# Altium schematic sheet Audio Codec.SchDoc
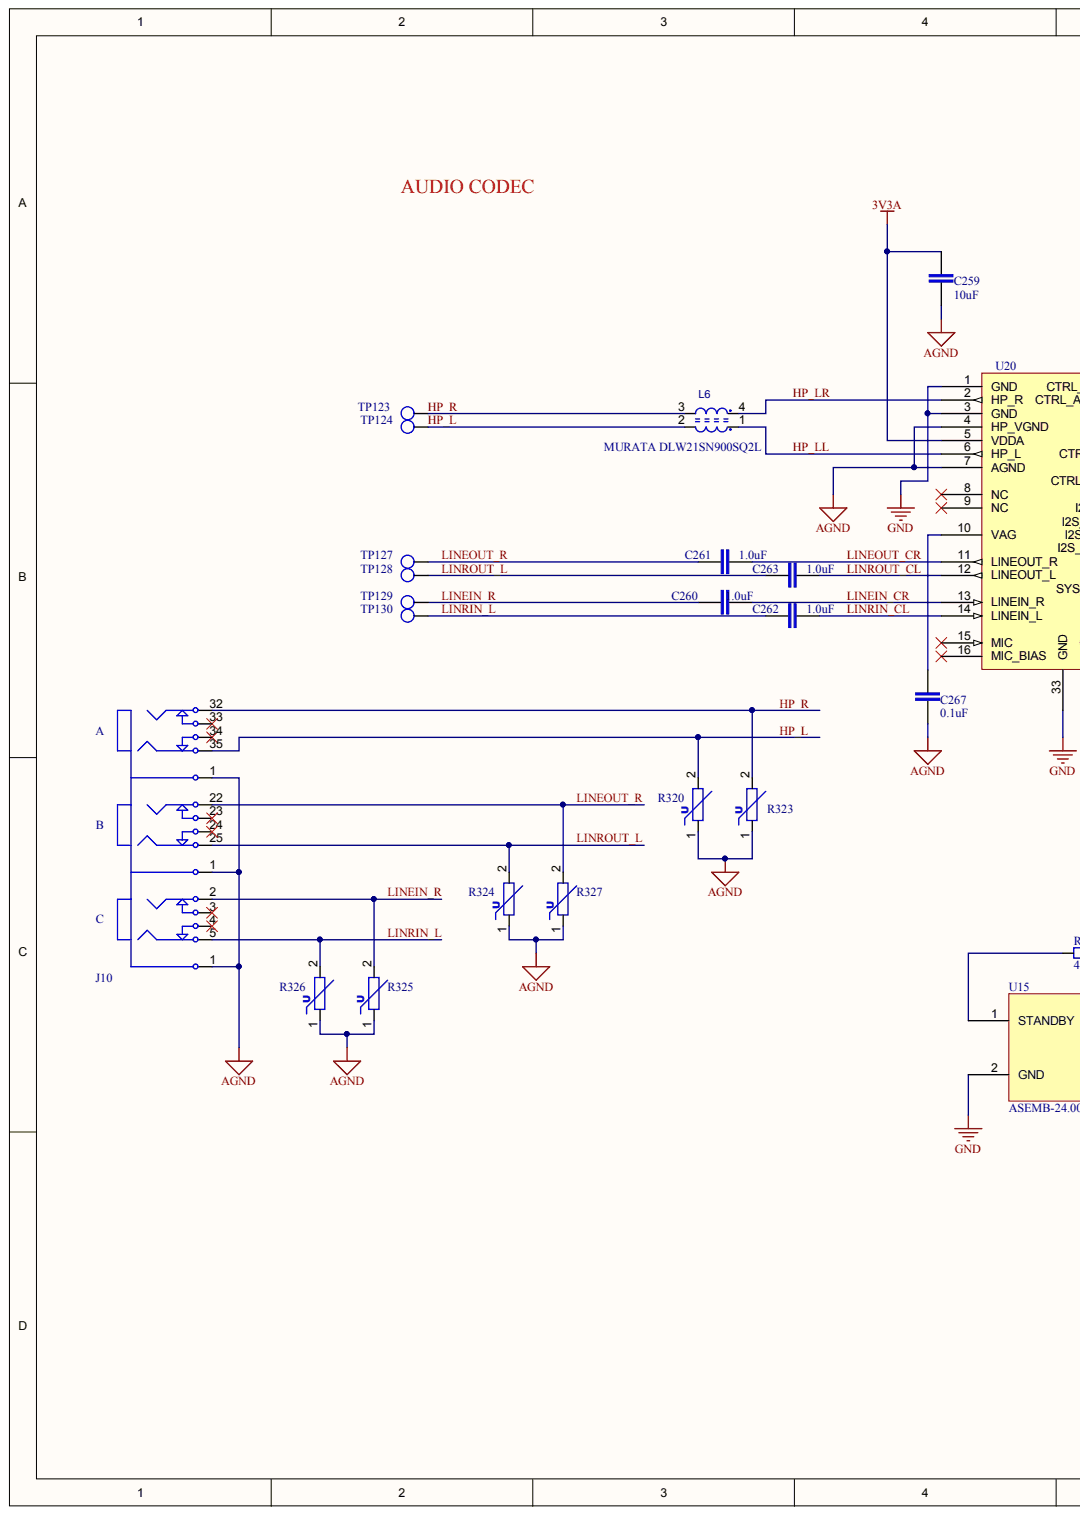
[diagram p0: Audio Codec.SchDoc, left]
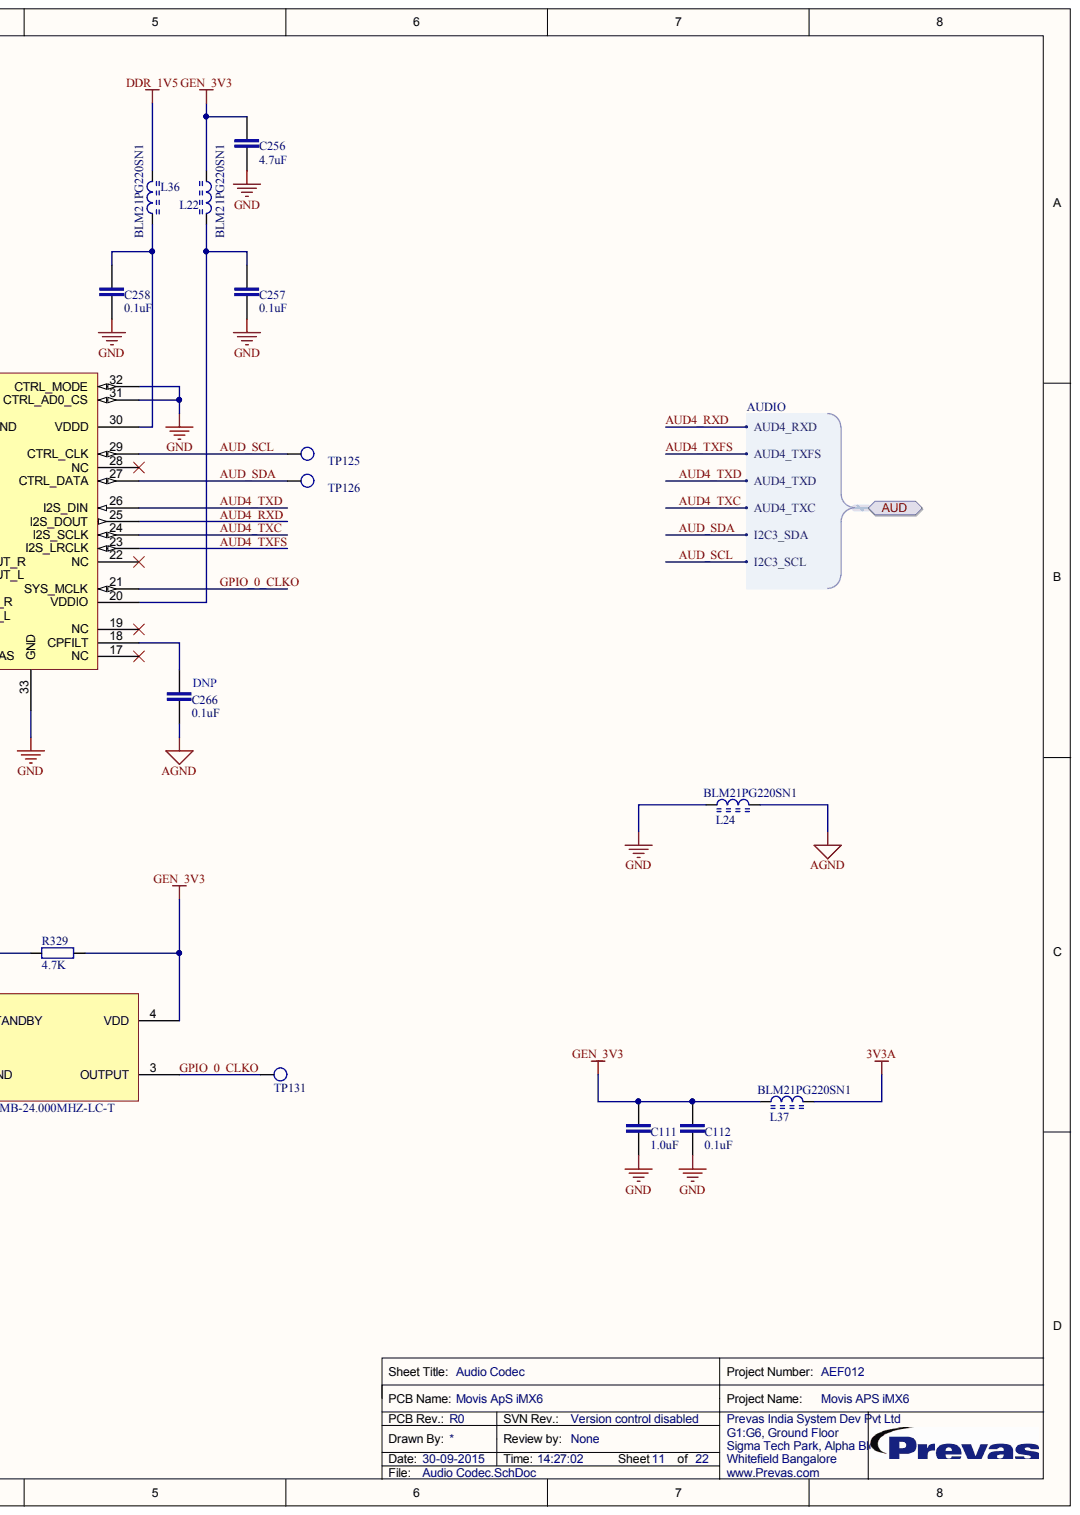
[diagram p1: Audio Codec.SchDoc, right]

## Parts
C111  1.0uF  [CAPC1608X90N]
C112  0.1uF  [CAPC1005X55N]
C256  4.7uF  [CAPC1608X90N]
C257  0.1uF  [CAPC1005X55N]
C258  0.1uF  [CAPC1005X55N]
C259  10uF  [CAPC1608X95N]
C260  1.0uF  [CAPC1608X90N]
C261  1.0uF  [CAPC1608X90N]
C262  1.0uF  [CAPC1608X90N]
C263  1.0uF  [CAPC1608X90N]
C266  0.1uF  Fitted: DNP  [CAPC1005X55N]
C267  0.1uF  [CAPC1005X55N]
J10  STX-4335-5BGP-S1  [JACK_3.5MM_STACKED]  (value not drawn)
L6  MURATA DLW21SN900SQ2L  [IND_DLP11S_DLP31S]
L22  BLM21PG220SN1  [INDC2012X110N]
L24  BLM21PG220SN1  [INDC2012X110N]
L36  BLM21PG220SN1  [INDC2012X110N]
L37  BLM21PG220SN1  [INDC2012X110N]
R320  V9MLA0603LNR  [RESC1608X55N]  (value not drawn)
R323  V9MLA0603LNR  [RESC1608X55N]  (value not drawn)
R324  V9MLA0603LNR  [RESC1608X55N]  (value not drawn)
R325  V9MLA0603LNR  [RESC1608X55N]  (value not drawn)
R326  V9MLA0603LNR  [RESC1608X55N]  (value not drawn)
R327  V9MLA0603LNR  [RESC1608X55N]  (value not drawn)
R329  4.7K  [RESC1608X55N]
TP123  Testpoint  [TP_SMD]  (value not drawn)  (no BOM)
TP124  Testpoint  [TP_SMD]  (value not drawn)  (no BOM)
TP125  Testpoint  [TP_SMD]  (value not drawn)  (no BOM)
TP126  Testpoint  [TP_SMD]  (value not drawn)  (no BOM)
TP127  Testpoint  [TP_SMD]  (value not drawn)  (no BOM)
TP128  Testpoint  [TP_SMD]  (value not drawn)  (no BOM)
TP129  Testpoint  [TP_SMD]  (value not drawn)  (no BOM)
TP130  Testpoint  [TP_SMD]  (value not drawn)  (no BOM)
TP131  Testpoint  [TP_SMD]  (value not drawn)  (no BOM)
U15  ASEMB-24.000MHZ-LC-T  [DFN210P323X90-4N]
U20  SGTL5000  [QFN50P500X500X90-33N]  (value not drawn)

## Ports
AUD, AUD.AUD4_RXD, AUD.AUD4_TXC, AUD.AUD4_TXD, AUD.AUD4_TXFS, AUD.I2C3_SCL, AUD.I2C3_SDA

## Nets
3V3A: C259.2 L37.2 U20.5(VDDA)
AGND: C259.1 C266.1 C267.1 J10.1 L24.2 R320.1 R323.1 R324.1 R325.1 R326.1 R327.1 U20.4(HP_VGND) U20.7(AGND)
DDR_1V5: L36.2
GEN_3V3: C111.2 C112.2 C256.2 L22.1 L37.1 R329.1 U15.4(VDD)
GND: C111.1 C112.1 C256.1 C257.1 C258.1 L24.1 U15.2(GND) U20.1(GND) U20.3(GND) U20.31(CTRL_AD0_CS) U20.32(CTRL_MODE) U20.33(GND)
AUD4_RXD: U20.25(I2S_DOUT) ; port AUD
AUD4_TXC: U20.24(I2S_SCLK) ; port AUD
AUD4_TXD: U20.26(I2S_DIN) ; port AUD
AUD4_TXFS: U20.23(I2S_LRCLK) ; port AUD
AUD_SCL: TP125.1 U20.29(CTRL_CLK) ; port AUD
AUD_SDA: TP126.1 U20.27(CTRL_DATA) ; port AUD
GPIO_0_CLKO: TP131.1 U15.3(OUTPUT) U20.21(SYS_MCLK)
HP_L: J10.35 L6.2 R320.2 TP124.1
HP_LL: L6.1 U20.6(HP_L)
HP_LR: L6.4 U20.2(HP_R)
HP_R: J10.32 L6.3 R323.2 TP123.1
LINEIN_CR: C260.1 U20.13(LINEIN_R)
LINEIN_R: C260.2 J10.2 R325.2 TP129.1
LINEOUT_CR: C261.1 U20.11(LINEOUT_R)
LINEOUT_R: C261.2 J10.22 R327.2 TP127.1
LINRIN_CL: C262.2 U20.14(LINEIN_L)
LINRIN_L: C262.1 J10.5 R326.2 TP130.1
LINROUT_CL: C263.2 U20.12(LINEOUT_L)
LINROUT_L: C263.1 J10.25 R324.2 TP128.1
NetC257_2: C257.2 L22.2 U20.20(VDDIO)
NetC258_2: C258.2 L36.1 U20.30(VDDD)
NetC266_2: C266.2 U20.18(CPFILT)
NetC267_2: C267.2 U20.10(VAG)
NetR329_2: R329.2 U15.1(STANDBY)

Not connected: J10 3 4 23 24 33 34; U20 8(NC) 9(NC) 15(MIC) 16(MIC_BIAS) 17(NC) 19(NC) 22(NC) 28(NC)

## Library data of the parts
C111 C260-C263 = INNISI-79: CAP CER 1UF 16V 10% X7R 0603; ref_part_type: /capacitor/non_polarized/ceramic/; source_manufacturer_1: Murata; source_manufacturer_1_pn: GRM188R71C105KE15D; cae_altium_pcb_status: Prototype; Database Table Name: altium_default; db_rev: 7576; elec_temp_range: -55 to +125; phy_height: 0.90mm; phy_mounting: SMD; phy_packaging_type: 0603 (1608 Metric); ref_datasheet: INNISI-33; ref_keyword: Ceramic Capacitor 0603; cae_altium_sch_status: Prototype; Component Kind: Standard; ComponentLink1Description: Datasheet; ComponentLink1URL: https://cop201.prevas.dk/viewvc/datasheet/INNISI-33.pdf?root=hw_components&view=co; db_created: 2015-08-03 05:39:06.0; db_last_updated: 03-08-2015 05:39:08; db_local_id: INNISI-79; db_status: Prototype; elec_tolerance: 10%; elec_value: 1.0uF; elec_voltage_rating: 16V; phy_material: X7R; Library Name: altium_mysql.dblib
C112 C257 C258 C266 C267 = INNISI-73: CAP CER 0.1UF 50V 10% X5R 0402; ref_part_type: /capacitor/non_polarized/ceramic/; source_manufacturer_1: TDK Corporation; source_manufacturer_1_pn: C1005X5R1H104K050BB; cae_altium_pcb_status: Prototype; Database Table Name: altium_default; db_rev: 7569; elec_temp_range: -55 to +85 C; phy_height: 0.55mm; phy_mounting: SMD; phy_packaging_type: 0402; ref_datasheet: INNISI-71; cae_altium_sch_status: Prototype; Component Kind: Standard; ComponentLink1Description: Datasheet; ComponentLink1URL: https://cop201.prevas.dk/viewvc/datasheet/INNISI-71.pdf?root=hw_components&view=co; db_created: 2015-08-03 03:34:59.0; db_last_updated: 03-08-2015 03:35:01; db_local_id: INNISI-73; db_status: Prototype; elec_tolerance: ±10%; elec_value: 0.1uF; elec_voltage_rating: 50V; phy_material: X5R; ref_keyword: CAP CER 0.1UF 50V 10% X5R 0402; Library Name: altium_mysql.dblib
C256 = INNISI-102: Ceramic capacitor X5R 0603; ref_part_type: /capacitor/non_polarized/ceramic/; source_manufacturer_1: TAIYO YUDEN; source_manufacturer_1_pn: EMK107ABJ475KA-T; cae_altium_pcb_status: Prototype; Database Table Name: altium_default; db_rev: 7663; elec_temp_range: -55 to +85; elec_tolerance: 10%; elec_voltage_rating: 16V; phy_height: 0.90mm; phy_mounting: SMD; phy_packaging_type: 0603; ref_keyword: Multilayer chip capacitor; cae_altium_sch_status: Prototype; Component Kind: Standard; ComponentLink1Description: Datasheet; db_created: 2015-08-04 09:58:51.0; db_last_updated: 04-08-2015 09:58:53; db_local_id: INNISI-102; db_status: Prototype; elec_value: 4.7uF; phy_material: X5R; Library Name: altium_mysql.dblib
C259 = INNISI-78: CAP CER 10UF 16V 10% X5R 0603; ref_part_type: /capacitor/non_polarized/ceramic/; source_manufacturer_1: Murata; source_manufacturer_1_pn: GRM188R61C106KAALD; cae_altium_pcb_status: Prototype; Database Table Name: altium_default; db_rev: 7574; elec_temp_range: -55 to +85C; phy_height: 0.95mm; phy_mounting: SMD; phy_packaging_type: 0603; ref_datasheet: INNISI-75; ref_keyword: Ceramic capacitor X5R 0603; cae_altium_sch_status: Prototype; Component Kind: Standard; ComponentLink1Description: Datasheet; ComponentLink1URL: https://cop201.prevas.dk/viewvc/datasheet/INNISI-75.pdf?root=hw_components&view=co; db_created: 2015-08-03 05:08:42.0; db_last_updated: 03-08-2015 05:08:44; db_local_id: INNISI-78; db_status: Prototype; elec_tolerance: 10%; elec_value: 10uF; elec_voltage_rating: 16V; phy_material: X5R; Library Name: altium_mysql.dblib
J10 = INNISI-62: Phone Connectors 3.5mm STACKED STEREO 5P BLU/5P GRN/5P PNK; ref_part_type: /connector/5 pins/; source_manufacturer_1: Kycon; cae_altium_pcb_status: Prototype; Database Table Name: altium_default; db_rev: 7461; elec_current_rating: 1A; elec_temp_range: -25C to +100C; elec_voltage_rating: 16V; phy_material: Copper Alloy; phy_mounting: Leaded; ref_datasheet: INNISI-61; ref_keyword: Audio Connectors; cae_altium_sch_status: Prototype; Component Kind: Standard; ComponentLink1Description: Datasheet; ComponentLink1URL: https://cop201.prevas.dk/viewvc/datasheet/INNISI-61.pdf?root=hw_components&view=co; db_created: 2015-06-18 05:59:17.0; db_last_updated: 18-06-2015 06:09:27; db_local_id: INNISI-62; db_status: Prototype; elec_value: STX-4335-5BGP-S1; source_manufacturer_1_pn: STX-4335-5BGP-S1; Library Name: altium_mysql.dblib
L6 = NIMA-45: Choke, Common Mode 90R@100MHz; ref_part_type: /inductor/lossy/; source_manufacturer_1_pn: DLP11SN900HL2L; cae_altium_pcb_status: Prototype; Database Table Name: altium_default; db_rev: 1364; elec_current_rating: 0.15A; elec_frequency_rating: 100MHz; elec_temp_range: -40 to 85; elec_tolerance: 20%; elec_voltage_rating: 5V; phy_height: 0.92mm; phy_material: Ferrite; phy_mounting: SMD; phy_packaging_type: 0504; ref_datasheet: NIMA-38; cae_altium_sch_status: Prototype; Component Kind: Standard; ComponentLink1Description: Datasheet; ComponentLink1URL: https://cop201.prevas.dk/viewvc/datasheet/NIMA-38.pdf?root=hw_components&view=co; db_created: 2009-03-25 17:22:37; db_last_updated: 02-06-2009 11:13:05; db_local_id: NIMA-45; db_status: Prototype; elec_value: MURATA DLW21SN900SQ2L; Mounted: Yes; ref_keyword: Choke, Common Mode 90R@100MHz; source_manufacturer_1: Murata; Library Name: altium_mysql.dblib
L22 L24 L36 L37 = INNISI-22: EMI Filter Beads, Chips & Arrays; ref_part_type: /inductor/power/; source_manufacturer_1: Murata; cae_altium_pcb_status: Prototype; Database Table Name: altium_default; db_rev: 7355; elec_current_rating: 6 A; elec_frequency_rating: 100 MHz; elec_temp_range: - 55 C to + 125 C; elec_tolerance: 25 %; phy_mounting: SMD; phy_packaging_type: 0805; ref_keyword: Ferrite Chip Beads; cae_altium_sch_status: Prototype; Component Kind: Standard; ComponentLink1Description: Datasheet; ComponentLink1URL: https://cop201.prevas.dk/viewvc/datasheet/INNISI-22.pdf?root=hw_components&view=co; db_created: 2015-06-01 11:11:12.0; db_last_updated: 02-06-2015 09:15:35; db_local_id: INNISI-22; db_status: Prototype; elec_value: BLM21PG220SN1; ref_datasheet: INNISI-22; source_manufacturer_1_pn: BLM21PG220SN1; Library Name: altium_mysql.dblib
R320 R323-R327 = INNISI-9: Varistors MULTI-LAYER VARISTOR SURFACE MOUNT; ref_part_type: /resistor/vdr/varistor/; source_manufacturer_1: Littelfuse; cae_altium_pcb_status: Prototype; Database Table Name: altium_default; db_rev: 7327; elec_temp_range: - 55 C to + 125 C; elec_voltage_rating: 9V; phy_mounting: SMD; cae_altium_sch_status: Prototype; Component Kind: Standard; ComponentLink1Description: Datasheet; ComponentLink1URL: https://cop201.prevas.dk/viewvc/datasheet/INNISI-9.pdf?root=hw_components&view=co; db_created: 2015-05-27 09:25:06.0; db_last_updated: 27-05-2015 09:25:08; db_local_id: INNISI-9; db_status: Prototype; elec_value: V9MLA0603LNR; ref_datasheet: INNISI-9; ref_keyword: Varistor; source_manufacturer_1_pn: V9MLA0603LNR; Library Name: altium_mysql.dblib
R329 = INVIHA-5: RES SMD 4.7K OHM 1% 1/10W 0603; ref_part_type: /resistor/fixed/; source_manufacturer_1: Yageo; source_manufacturer_1_pn: RC0603FR-074K7L; cae_altium_pcb_status: Prototype; Database Table Name: altium_default; db_rev: 7581; elec_power_rating: 0.1W; elec_temp_range: -55 to +155° C; phy_height: 0.55mm; phy_packaging_type: 0603; ref_datasheet: INVIHA-4; ref_keyword: Chip Resistor; cae_altium_sch_status: Prototype; Component Kind: Standard; ComponentLink1Description: Datasheet; ComponentLink1URL: https://cop201.prevas.dk/viewvc/datasheet/INVIHA-4.pdf?root=hw_components&view=co; db_created: 2015-08-03 06:09:48.0; db_last_updated: 03-08-2015 6:09:49 AM; db_local_id: INVIHA-5; db_status: Prototype; elec_tolerance: 1%; elec_value: 4.7K; phy_mounting: SMD; Library Name: altium_mysql.dblib
TP123-TP131 = JEPE-114: Test Point SMD; ref_part_type: /pcb_item/; cae_altium_pcb_status: Prototype; Database Table Name: altium_default; db_rev: 3281; cae_altium_sch_status: Prototype; ComponentLink1Description: Datasheet; db_created: 2008-08-18 11:39:42; db_last_updated: 23-03-2011 14:14:06; db_local_id: JEPE-114; db_status: Prototype; elec_value: Test Point; phy_mounting: SMD; ref_keyword: Test  Point; Library Name: altium_mysql.dblib
U15 = INNISI-17: Standard Clock Oscillators 24.000MHZ 50ppm; ref_part_type: /oscillator/; source_manufacturer_1: ABRACON; cae_altium_pcb_status: Prototype; Database Table Name: altium_default; db_rev: 7341; elec_frequency_rating: 24 MHz; elec_temp_range: -40 + 85C; elec_value: 24MHz; elec_voltage_rating: 1.8 V to 3.3 V; phy_mounting: SMD; cae_altium_sch_status: Prototype; Component Kind: Standard; ComponentLink1Description: Datasheet; ComponentLink1URL: https://cop201.prevas.dk/viewvc/datasheet/INNISI-17.pdf?root=hw_components&view=co; db_created: 2015-06-01 09:03:12.0; db_last_updated: 01-06-2015 09:31:41; db_local_id: INNISI-17; db_status: Prototype; ref_datasheet: INNISI-17; ref_keyword: Standard Clock Oscillators; source_manufacturer_1_pn: ASEMB-24.000MHZ-LC-T; Library Name: altium_mysql.dblib
U20 = CHPE-146: Audio codec; ref_part_type: /integrated/converter/da/; source_manufacturer_1: Freescale; source_manufacturer_1_pn: SGTL5000XNAA3; cae_altium_pcb_status: Prototype; Database Table Name: altium_default; db_rev: 3613; elec_temp_range: -40 to 85; elec_voltage_rating: 1,62 to 3.6V; phy_height: 0.9mm; phy_mounting: SMD; phy_packaging_type: 32QFN; ref_datasheet: TELA-55; cae_altium_sch_status: Prototype; Component Kind: Standard; ComponentLink1Description: Datasheet; ComponentLink1URL: https://cop201.prevas.dk/viewvc/datasheet/TELA-55.pdf?root=hw_components&view=co; db_created: 2011-06-07 12:51:03.0; db_last_updated: 10-06-2011 23:12:40; db_local_id: CHPE-146; db_status: Prototype; elec_value: SGTL5000; ref_keyword: SGTL5000; Library Name: altium_mysql.dblib
